# Altium schematic sheet Main.SchDoc
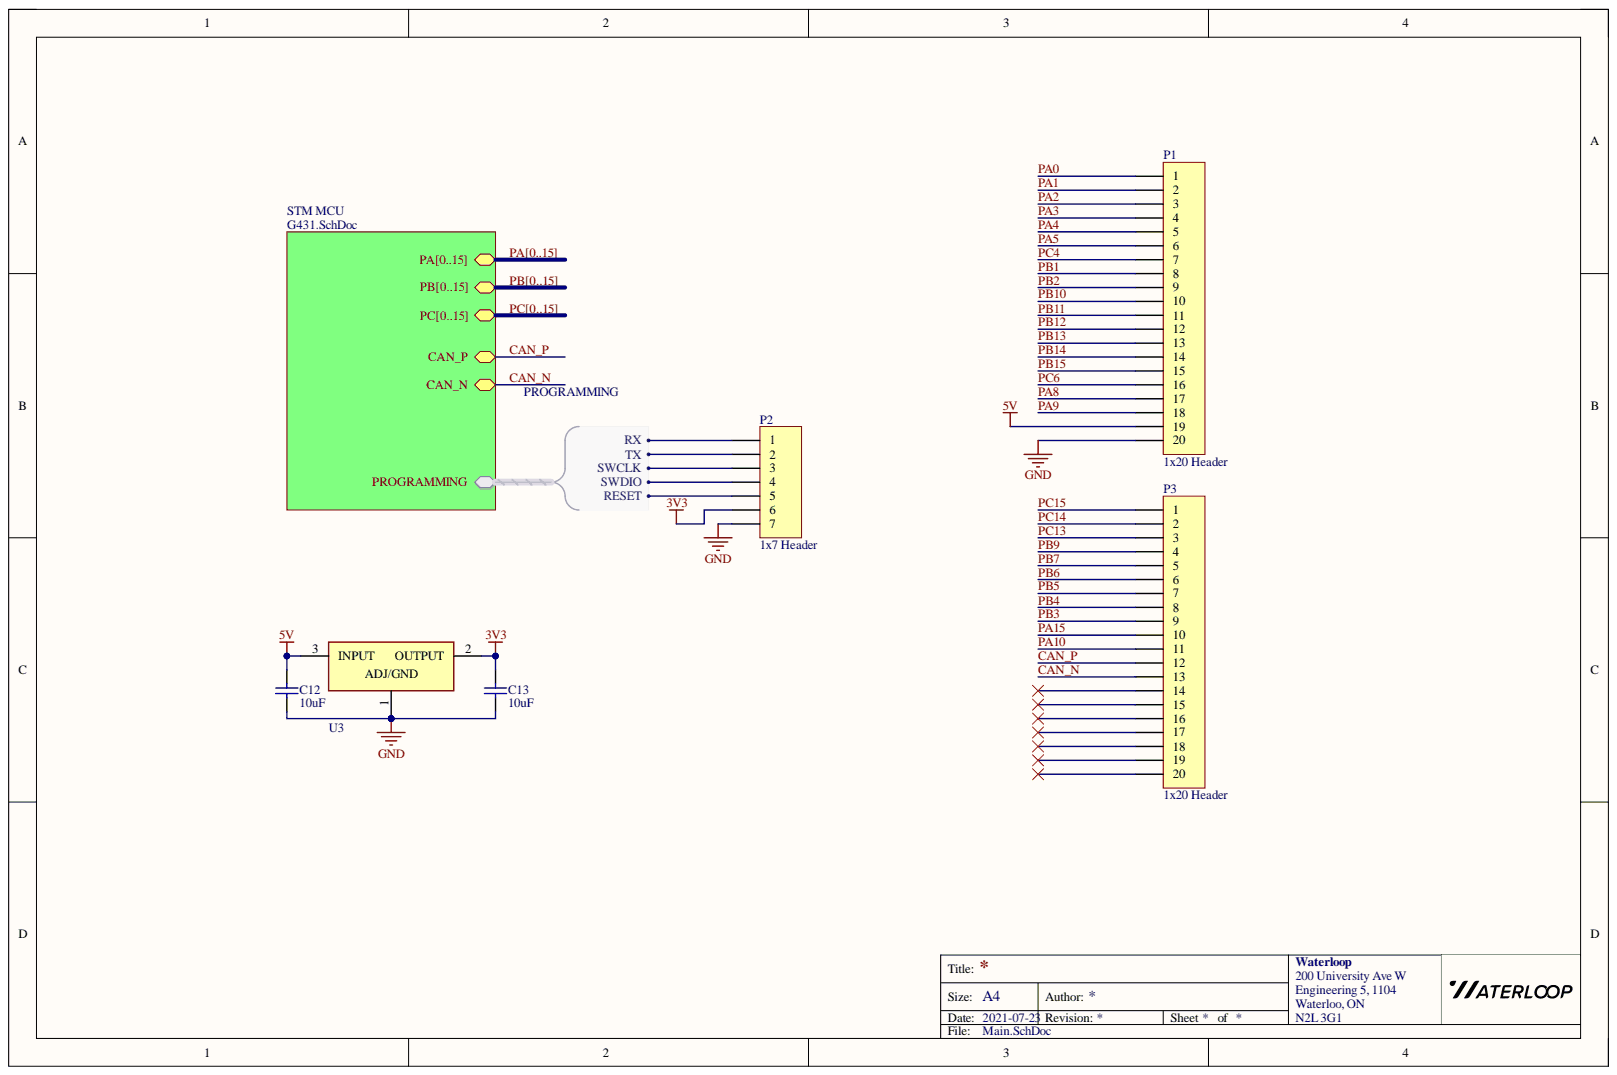
[diagram p0: Main.SchDoc]

## Parts
C12  value 10uF  [0805 - Capacitor]  (hidden comment: Capacitor - Nonpolarized)
C13  value 10uF  [0805 - Capacitor]  (hidden comment: Capacitor - Nonpolarized)
P1  1x20 Header  [HDR1X20]
P2  1x7 Header  [HDR1X7]
P3  1x20 Header  [HDR1X20]
U3  TLV1117-33IDCYR  [TLV1117]  (value not drawn)

## Nets
3V3: C13.2 P2.6 U3.2
5V: C12.2 P1.19 U3.3
GND: C12.1 C13.1 P1.20 P2.7 U3.1
CAN_N: P3.13
CAN_P: P3.12
PA0: P1.1  [also labelled PA]
PA1: P1.2  [also labelled PA]
PA2: P1.3  [also labelled PA]
PA3: P1.4  [also labelled PA]
PA4: P1.5  [also labelled PA]
PA5: P1.6  [also labelled PA]
PA8: P1.17  [also labelled PA]
PA9: P1.18  [also labelled PA]
PA10: P3.11  [also labelled PA]
PA15: P3.10  [also labelled PA]
PB1: P1.8  [also labelled PB]
PB2: P1.9  [also labelled PB]
PB3: P3.9  [also labelled PB]
PB4: P3.8  [also labelled PB]
PB5: P3.7  [also labelled PB]
PB6: P3.6  [also labelled PB]
PB7: P3.5  [also labelled PB]
PB9: P3.4  [also labelled PB]
PB10: P1.10  [also labelled PB]
PB11: P1.11  [also labelled PB]
PB12: P1.12  [also labelled PB]
PB13: P1.13  [also labelled PB]
PB14: P1.14  [also labelled PB]
PB15: P1.15  [also labelled PB]
PC4: P1.7  [also labelled PC]
PC6: P1.16  [also labelled PC]
PC13: P3.3  [also labelled PC]
PC14: P3.2  [also labelled PC]
PC15: P3.1  [also labelled PC]
NetP2_1: P2.1
NetP2_2: P2.2
NetP2_3: P2.3
NetP2_4: P2.4
NetP2_5: P2.5
NetP3_14: P3.14
NetP3_15: P3.15
NetP3_16: P3.16
NetP3_17: P3.17
NetP3_18: P3.18
NetP3_19: P3.19
NetP3_20: P3.20
PA6:  [also labelled PA]
PA7:  [also labelled PA]
PA11:  [also labelled PA]
PA12:  [also labelled PA]
PA13:  [also labelled PA]
PA14:  [also labelled PA]
PB0:  [also labelled PB]
PB8:  [also labelled PB]
PC0:  [also labelled PC]
PC1:  [also labelled PC]
PC2:  [also labelled PC]
PC3:  [also labelled PC]
PC5:  [also labelled PC]
PC7:  [also labelled PC]
PC8:  [also labelled PC]
PC9:  [also labelled PC]
PC10:  [also labelled PC]
PC11:  [also labelled PC]
PC12:  [also labelled PC]

## Library data of the parts
C12 C13 = Capacitor - Nonpolarized: Nonpolarized Capacitor; Component Kind: Standard; Library Name: General.SchLib; Signal Integrity: Cap; Simulation: CAP
P1 P3 = 1x20 Header: 1x20 Pin Header; Component Kind: Standard; Library Name: GeneralConnectors.SchLib
P2 = 1x7 Header: 1x7 Pin Header; Component Kind: Standard; Library Name: GeneralConnectors.SchLib; Signal Integrity: Connector
U3 = TLV1117-33IDCYR: Linear Voltage Regulator IC 3.3V 800MA SOT223-4; Manufacturer: Texas Instruments; Component Kind: Standard; ComponentLink1Description: Datasheet; ComponentLink1URL: http://www.ti.com/lit/ds/symlink/tlv1117.pdf?HQS=TI-null-null-digikeymode-df-pf-null-wwe&ts=1590668900314; Library Name: GeneralComponents.SchLib; Manufacturer Part Number: TLV1117-33IDCYR; Supplier: Digikey; Supplier Part Number: 296-21112-1-ND
